# Altium schematic sheet CAN.SchDoc
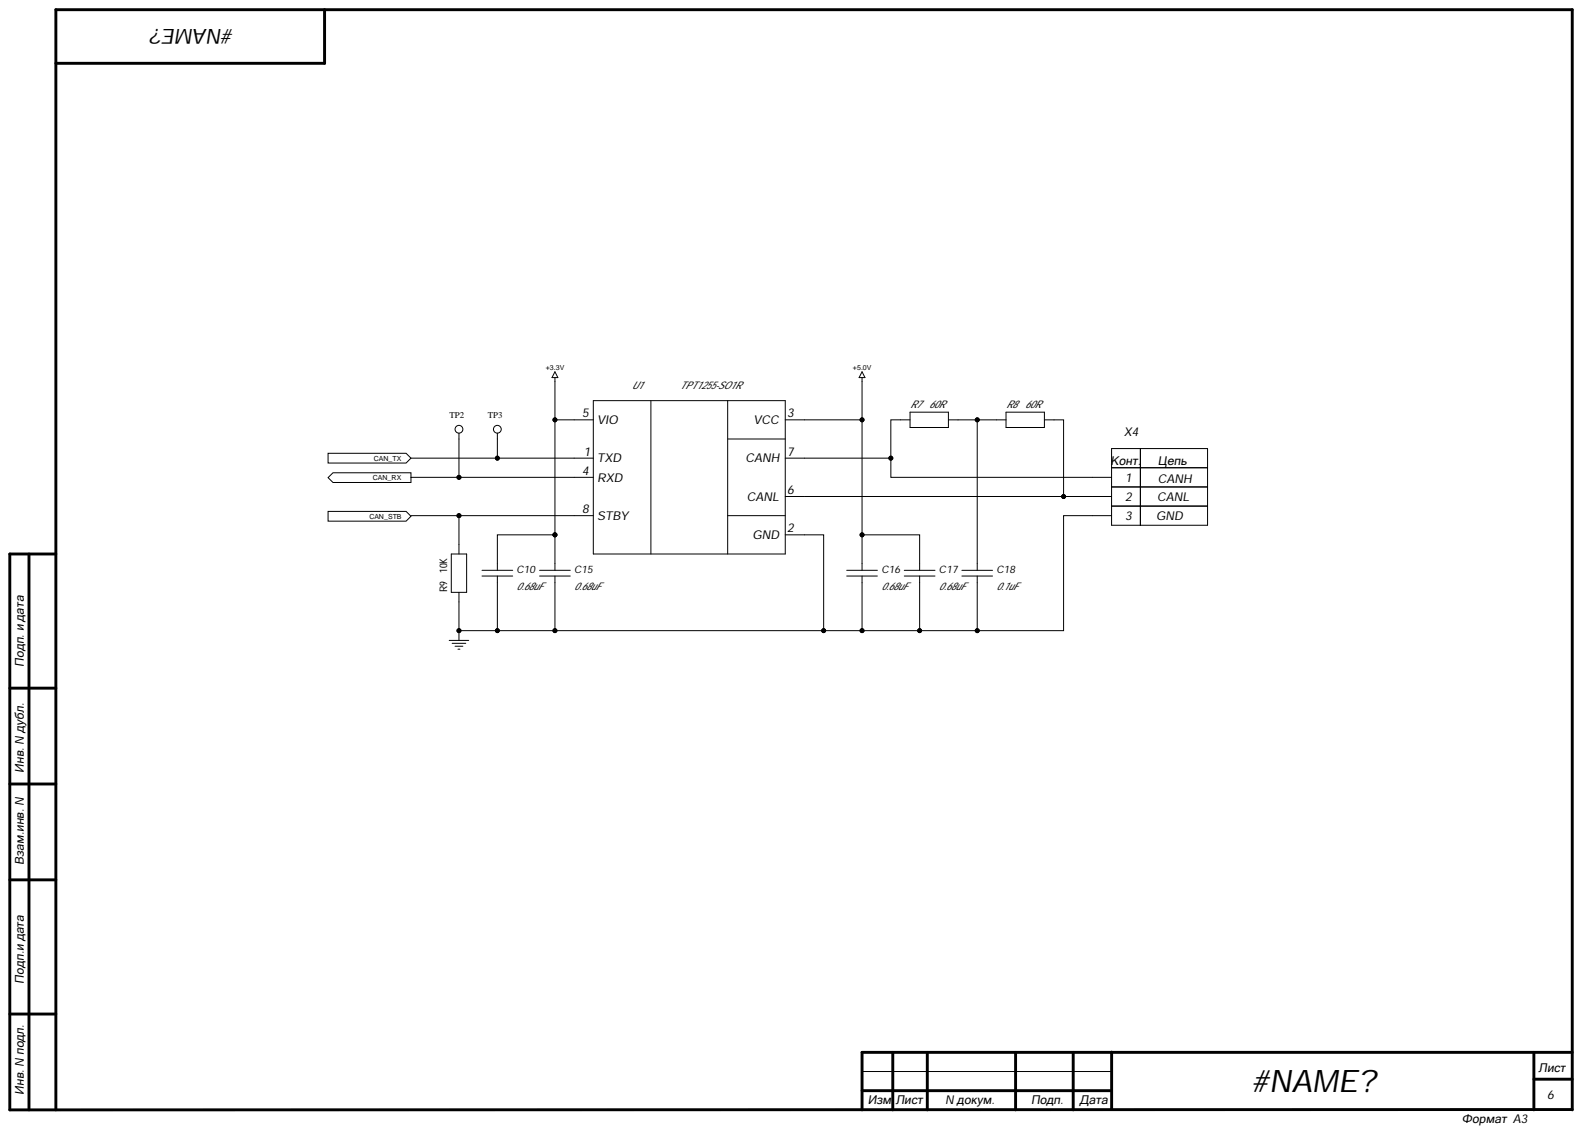
[diagram p0: CAN.SchDoc]

## Parts
C10  value 0.68uF  (hidden comment: Cap)
C15  value 0.68uF  (hidden comment: Cap)
C16  value 0.68uF  (hidden comment: Cap)
C17  value 0.68uF  (hidden comment: Cap)
C18  value 0.1uF  (hidden comment: Cap)
R7  value 60R  (hidden comment: Res2)
R8  value 60R  (hidden comment: Res2)
R9  value 10K  (hidden comment: Res2)
TP2  (no value)  [TP_0MM5_1MM0]  (no BOM)
TP3  (no value)  [TP_0MM5_1MM0]  (no BOM)
U1  TPT1255-SO1R  [Qfn32-ftdi]
X4  Circuit 1: CANH  Circuit 2: CANL  Circuit 3: GND

## Ports
CAN_RX, CAN_STB, CAN_TX

## Nets
+3.3V: C10.2 C15.2 U1.5(VIO)
+5.0V: C16.2 C17.2 U1.3(VCC)
GND: C10.1 C15.1 C16.1 C17.1 C18.1 R9.2 U1.2(GND) X4.3
CAN_RX: TP2.1 U1.4(RXD) ; port CAN_RX
CAN_TX: TP3.1 U1.1(TXD) ; port CAN_TX
NetC18_2: C18.2 R7.1 R8.2
NetR7_2: R7.2 U1.7(CANH) X4.1
NetR8_1: R8.1 U1.6(CANL) X4.2
NetR9_1: R9.1 U1.8(STBY)
N00001: ; port CAN_STB

## Library data of the parts
C10 C16 = Cap: Конденсатор; Value: 0.68uF; Component Kind: Standard; PackageReference: 0603; Signal Integrity: Cap; Library Name: Terra.SCHLIB
C15 C17 C18 = Cap: Конденсатор; Component Kind: Standard; PackageReference: 0603; Signal Integrity: Cap; Library Name: Terra.SCHLIB
R7-R9 = Res2: Резистор; Component Kind: Standard; PackageReference: 0603; Signal Integrity: Res; Library Name: Terra.SCHLIB
TP2 TP3 = Test_Point: Тестовая точка на плате; MarkPCB: +5V
U1 = FT232R_2: CAN Transceiver; Part Class: ICS; Device: U17; Item Num: P01-17819R; Num Pins: 32; Component Kind: Standard; Library Name: common.SCHLIB
X4 = CONNECTOR_6: Component Kind: Standard; System: connector
